# Altium schematic sheet ArcadeTestHAT 05-1.1 MCU.SchDoc
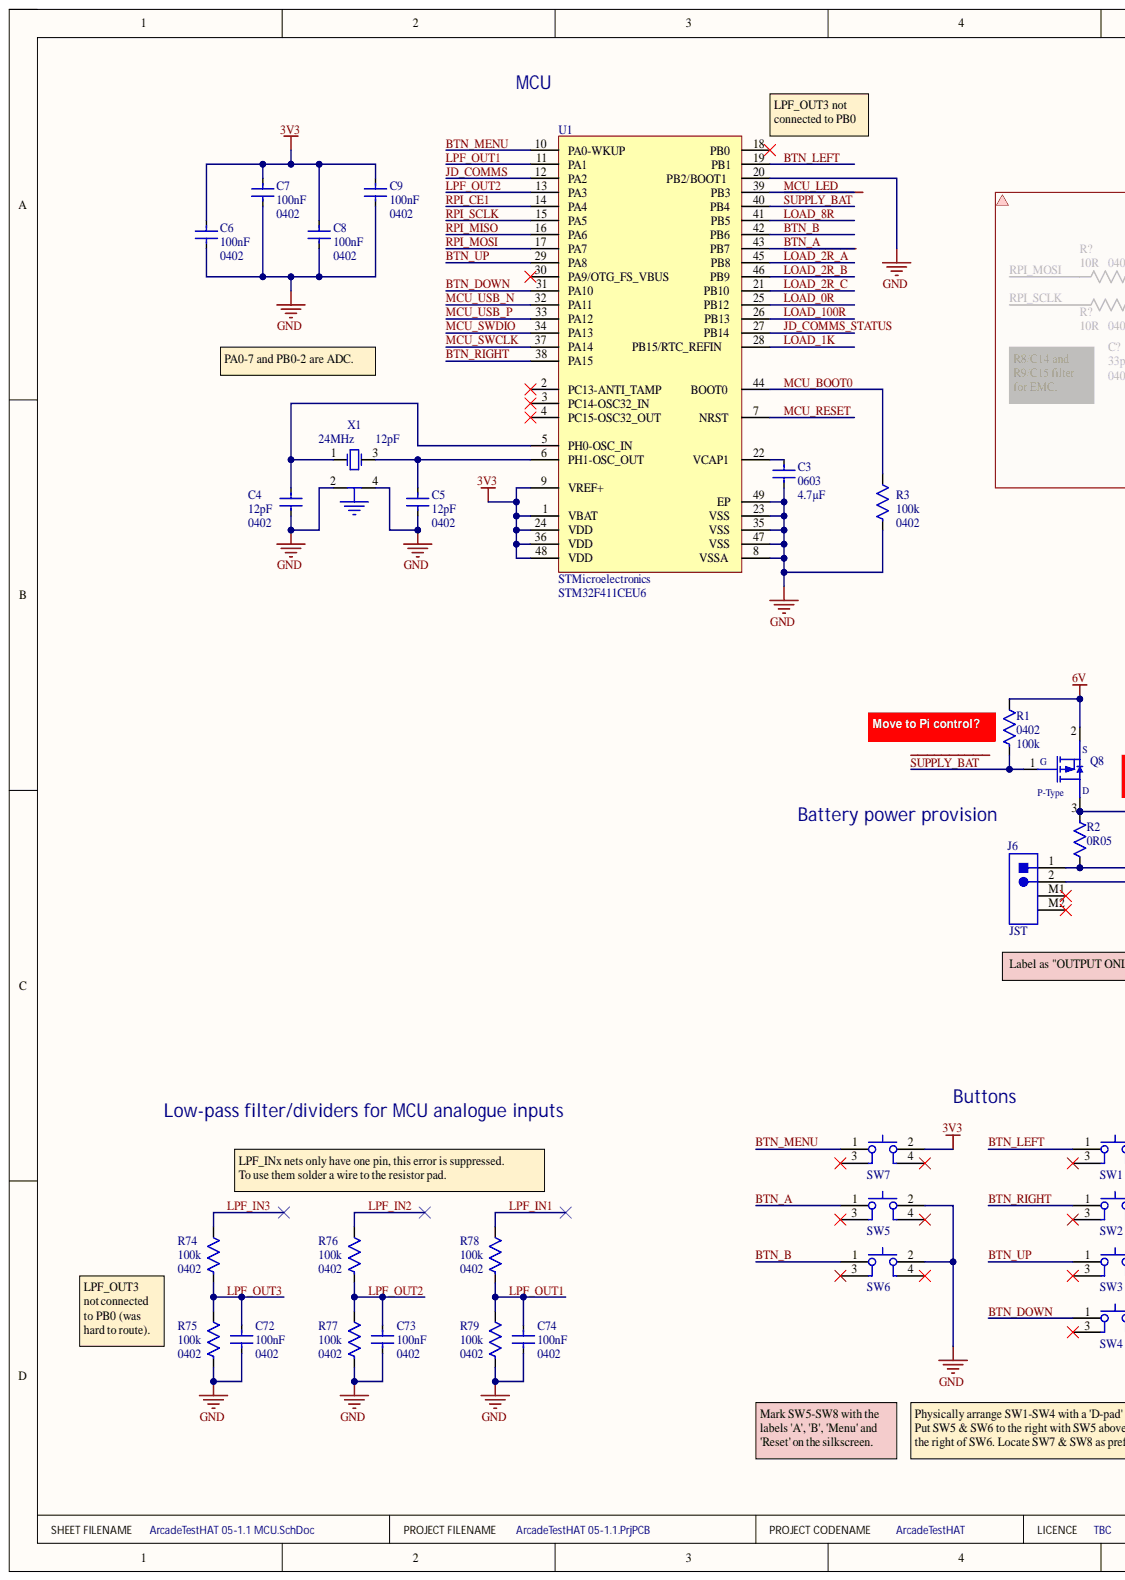
[diagram p0: ArcadeTestHAT 05-1.1 MCU.SchDoc, left]
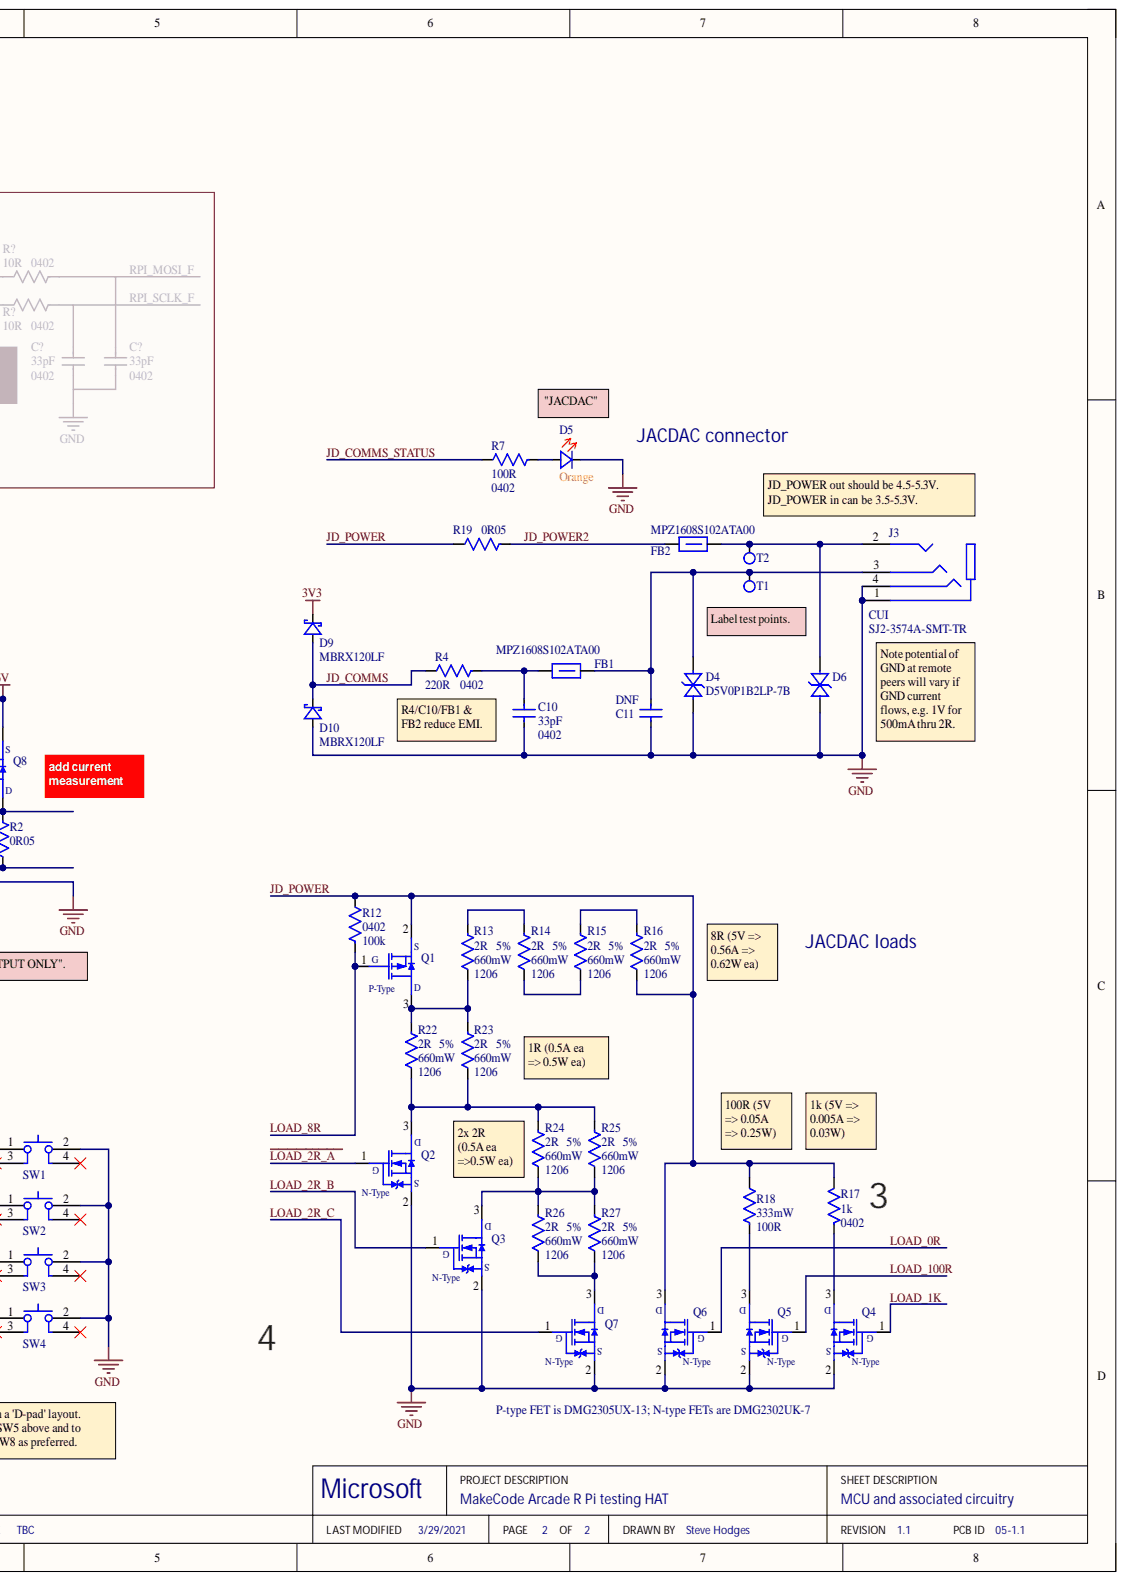
[diagram p1: ArcadeTestHAT 05-1.1 MCU.SchDoc, right]

## Parts
C3  Capacitance: 4.7µF  Case Code (Imperial): 0603
C4  value 12pF  Package Size: 0402
C5  value 12pF  Package Size: 0402
C6  Capacitance: 100nF  Case Code (Imperial): 0402
C7  Capacitance: 100nF  Case Code (Imperial): 0402
C8  Capacitance: 100nF  Case Code (Imperial): 0402
C9  Capacitance: 100nF  Case Code (Imperial): 0402
C10  value 33pF  Package Size: 0402
C11  (no value)
C72  Capacitance: 100nF  Case Code (Imperial): 0402
C73  Capacitance: 100nF  Case Code (Imperial): 0402
C74  Capacitance: 100nF  Case Code (Imperial): 0402
D4  Manufacturer Part Number 1: D5V0P1B2LP-7B
D5  Colour: Orange
D6  (no value)
D9  (no value)
D10  (no value)
FB1  Manufacturer Part Number: MPZ1608S102ATA00
FB2  Manufacturer Part Number: MPZ1608S102ATA00
J3  Manufacturer: CUI  Manufacturer Part Number: SJ2-3574A-SMT-TR
J6  Manufacturer: JST
Q1  (no value)
Q2  (no value)
Q3  (no value)
Q4  (no value)
Q5  Manufacturer Part Number: DMG2302UK-7
Q6  (no value)
Q7  (no value)
Q8  (no value)
R1  Case Code (Imperial): 0402  Resistance: 100k
R2  value 0R05
R3  Case Code (Imperial): 0402  Resistance: 100k
R4  value 220R  Case: 0402
R7  value 100R  Case: 0402
R12  Case Code (Imperial): 0402  Resistance: 100k
R13  Case Code (Imperial): 1206  Power Rating: 660mW  Resistance: 2R  Tolerance: 5%
R14  Case Code (Imperial): 1206  Power Rating: 660mW  Resistance: 2R  Tolerance: 5%
R15  Case Code (Imperial): 1206  Power Rating: 660mW  Resistance: 2R  Tolerance: 5%
R16  Case Code (Imperial): 1206  Power Rating: 660mW  Resistance: 2R  Tolerance: 5%
R17  value 1k  Case: 0402
R18  Max Power Dissipation: 333mW  Resistance: 100R
R19  value 0R05
R22  Case Code (Imperial): 1206  Power Rating: 660mW  Resistance: 2R  Tolerance: 5%
R23  Case Code (Imperial): 1206  Power Rating: 660mW  Resistance: 2R  Tolerance: 5%
R24  Case Code (Imperial): 1206  Power Rating: 660mW  Resistance: 2R  Tolerance: 5%
R25  Case Code (Imperial): 1206  Power Rating: 660mW  Resistance: 2R  Tolerance: 5%
R26  Case Code (Imperial): 1206  Power Rating: 660mW  Resistance: 2R  Tolerance: 5%
R27  Case Code (Imperial): 1206  Power Rating: 660mW  Resistance: 2R  Tolerance: 5%
R74  Case Code (Imperial): 0402  Resistance: 100k
R75  Case Code (Imperial): 0402  Resistance: 100k
R76  Case Code (Imperial): 0402  Resistance: 100k
R77  Case Code (Imperial): 0402  Resistance: 100k
R78  Case Code (Imperial): 0402  Resistance: 100k
R79  Case Code (Imperial): 0402  Resistance: 100k
SW1  (no value)
SW2  (no value)
SW3  (no value)
SW4  (no value)
SW5  (no value)
SW6  (no value)
SW7  (no value)
T1  (no value)
T2  (no value)
U1  Manufacturer: STMicroelectronics  Manufacturer Part Number: STM32F411CEU6
X1  Frequency: 24MHz  Loading capacitance: 12pF

## Nets
3V3: C6.2 C7.2 C8.2 C9.2 D9.1 SW7.2 U1.1(VBAT) U1.9(VREF+) U1.24(VDD) U1.36(VDD) U1.48(VDD)
6V: Q8.2 R1.1
GND: C3.1 C4.1 C5.1 C6.1 C7.1 C8.1 C9.1 C10.1 C11.1 C72.1 C73.1 C74.1 D4.2 D5.1 D6.2 D10.2 J3.1 J3.4 J6.2 Q2.2 Q3.2 Q4.2 Q5.2 Q6.2 Q7.2 R3.2 R75.2 R77.2 R79.2 SW1.2 SW2.2 SW3.2 SW4.2 SW5.2 SW6.2 U1.8(VSSA) U1.20(PB2/BOOT1) U1.23(VSS) U1.35(VSS) U1.47(VSS) U1.49(EP) X1.2 X1.4
~{LOAD_2R_A}: Q2.1 U1.45(PB8)
~{SUPPLY_BAT}: Q8.1 R1.2 U1.40(PB4)
BTN_A: SW5.1 U1.43(PB7)
BTN_B: SW6.1 U1.42(PB6)
BTN_DOWN: SW4.1 U1.31(PA10)
BTN_LEFT: SW1.1 U1.19(PB1)
BTN_MENU: SW7.1 U1.10(PA0-WKUP)
BTN_RIGHT: SW2.1 U1.38(PA15)
BTN_UP: SW3.1 U1.29(PA8)
JD_COMMS: D9.2 D10.1 R4.1 U1.12(PA2)
JD_COMMS_STATUS: R7.1 U1.27(PB14)
JD_POWER: Q1.2 Q6.3 R12.1 R16.2 R17.1 R18.1 R19.1
JD_POWER2: FB2.1 R19.2
LOAD_0R: Q6.1 U1.25(PB12)
LOAD_1K: Q4.1 U1.28(PB15/RTC_REFIN)
LOAD_2R_B: Q3.1 U1.46(PB9)
LOAD_2R_C: Q7.1 U1.21(PB10)
LOAD_8R: Q1.1 R12.2 U1.41(PB5)
LOAD_100R: Q5.1 U1.26(PB13)
LPF_IN1: R78.1
LPF_IN2: R76.1
LPF_IN3: R74.1
LPF_OUT1: C74.2 R78.2 R79.1 U1.11(PA1)
LPF_OUT2: C73.2 R76.2 R77.1 U1.13(PA3)
LPF_OUT3: C72.2 R74.2 R75.1
MCU_BOOT0: R3.1 U1.44(BOOT0)
MCU_LED: U1.39(PB3)
MCU_RESET: U1.7(NRST)
MCU_SWCLK: U1.37(PA14)
MCU_SWDIO: U1.34(PA13)
MCU_USB_N: U1.32(PA11)
MCU_USB_P: U1.33(PA12)
RPI_CE1: U1.14(PA4)
RPI_MISO: U1.16(PA6)
RPI_MOSI: U1.17(PA7)
RPI_SCLK: U1.15(PA5)
NetC3_2: C3.2 U1.22(VCAP1)
NetC4_2: C4.2 U1.5(PH0-OSC_IN) X1.1
NetC5_2: C5.2 U1.6(PH1-OSC_OUT) X1.3
NetC10_2: C10.2 FB1.1 R4.2
NetC11_2: C11.2 D4.1 FB1.2 J3.3 T1.1
NetD5_2: D5.2 R7.2
NetD6_1: D6.1 FB2.2 J3.2 T2.1
NetJ6_1: J6.1 R2.1
NetQ1_3: Q1.3 R13.2 R22.1 R23.1
NetQ2_3: Q2.3 R22.2 R23.2 R24.1 R25.1
NetQ3_3: Q3.3 R24.2 R25.2 R26.1 R27.1
NetQ4_3: Q4.3 R17.2
NetQ5_3: Q5.3 R18.2
NetQ7_3: Q7.3 R26.2 R27.2
NetQ8_3: Q8.3 R2.2
NetR13_1: R13.1 R14.1
NetR14_2: R14.2 R15.2
NetR15_1: R15.1 R16.1

Not connected: J6 M1 M2; SW1 3 4; SW2 3 4; SW3 3 4; SW4 3 4; SW5 3 4; SW6 3 4; SW7 3 4; U1 2(PC13-ANTI_TAMP) 3(PC14-OSC32_IN) 4(PC15-OSC32_OUT) 18(PB0) 30(PA9/OTG_FS_VBUS)

## Library data of the parts
C3 = (no symbol name): Generic 0603 capacitor 4.7uF 10V, e.g. Samsung CL10A475KP8NNNC; Dielectric: X5R; Tolerance: 10%; Case Code (Metric): 1608; Case/Package: 0603; Contact Plating: Tin; Manufacturer: Samsung; Manufacturer Part Number: CL10A475KP8NNNC; Max Operating Temperature: 85°C; Min Operating Temperature: -55°C; Supplier 1: Digi-Key; Supplier 2: Farnell; Supplier Part Number 1: 1276-1044-1-ND; Supplier Part Number 2: 3010071; Voltage Rating: 10V
C4 C5 = (no symbol name): Generic 0402 capacitor 12pF; Rated Voltage: * V; Manufacturer: Generic
C6-C9 C72-C74 = (no symbol name): Generic 0402 capacitor 100nF 16V, e.g. AVX 0402YG104ZAT2A; Manufacturer: Kyocera AVX; Dielectric: Y5V; Dissipation Factor: 9%; Insulation Resistance: 100GR; Tolerance: 80%; Case Code (Metric): 1005; Case/Package: 0402; ComponentLink2Description: AVX datasheet online; ComponentLink2URL: http://datasheets.avx.com/Y5V.pdf; Contact Plating: Tin,Matte; Manufacturer Part Number: 0402YG104ZAT2A; Max Operating Temperature: 85°C; Min Operating Temperature: -30°C; Supplier 1: Digi-Key; Supplier 2: Farnell; Supplier 3: Mouser; Supplier 4: RSComponents; Supplier Part Number 1: 478-1138-1-ND; Supplier Part Number 2: 1327667; Supplier Part Number 3: 581-040216G104Z; Supplier Part Number 4: 698-3190; Termination: SMD/SMT; Voltage: 16V; Voltage Rating: 16V; Voltage Rating (DC): 16V
C10 = (no symbol name): Generic 0402 capacitor 33pF; Rated Voltage: * V; Manufacturer: Generic
C11 = (no symbol name): generic 0402 capacitor; Rated Voltage: * V; Value: 33pF; Package Size: 0402
D4 = (no symbol name): ESD Suppressor, low parasitic capacitance.TVS DIODE 5.5V 13V DFN1006-2; Manufacturer 1: Diodes; Breakdown Voltage: 7V; Direction: Bidirectional; Element Configuration: Single; ESD Protection: Yes; Max Power Dissipation: 250mW; Max Reverse Leakage Current: 100nA; Max Surge Current: 4A; Min Breakdown Voltage: 6V; Number of Bidirectional Channels: 1; Power Line Protection: No; Case/Package: DFN; Category: TVS Diodes; Clamping Voltage: 13V; ComponentLink3Description: https://www.diodes.com/assets/Datasheets/D5V0P1B2LP.pdf?src-supplier=Digi-Key; ComponentLink3URL: https://www.diodes.com/assets/Datasheets/D5V0P1B2LP.pdf?src-supplier=Digi-Key; Contact Plating: Gold; Max Operating Temperature: 150°C; Min Operating Temperature: -65°C; Number of Pins: 2; Peak Pulse Current: 4A; Peak Pulse Power: 250mW; Polarity: Bidirectional; Resistor Type: Zener; Reverse Standoff Voltage: 5.5V; Supplier 1: Digi-Key; Supplier 2: Mouser; Supplier Part Number 1: D5V0P1B2LP-7BDICT-ND; Supplier Part Number 2: 621-D5V0P1B2LP-7B; Termination: SMD/SMT; Working Voltage: 5.5V
D5 = (no symbol name): 1.6 x 0.8 x 0.55mm (0603) LED 605nm Orange; Manufacturer: Rohm Semiconductor; Manufacturer Part Number: SML-D12D8WT86; Current - Test: 20mA; Lens Style/Size: Rectangle with Flat Top, 1.2mm x 0.8mm; Lens Type: Diffused, White; Millicandela Rating: 100mcd; Voltage - Forward (Vf) (Typ): 2.2V; Category: Optoelectronics; ComponentLink1Description: http://rohmfs.rohm.com/en/products/databook/datasheet/opto/led/chip_mono/sml-d1.pdf; ComponentLink1URL: http://rohmfs.rohm.com/en/products/databook/datasheet/opto/led/chip_mono/sml-d1.pdf; Luminous Flux @ Current - Test: -; Package / Case: 0603 (1608 Metric); Size / Dimension: 1.60mm L x 0.80mm W; Supplier 1: Digi-Key; Supplier Part Number 1: 511-1577-1-ND; Viewing Angle: -; Wavelength - Dominant: 605nm; Wavelength - Peak: -
D6 = (no symbol name): ESD Suppressor, low parasitic capacitance.TVS DIODE 5.5V 13V DFN1006-2; Manufacturer 1: Diodes; Manufacturer Part Number 1: D5V0P1B2LP-7B; Breakdown Voltage: 7V; Direction: Bidirectional; Element Configuration: Single; ESD Protection: Yes; Max Power Dissipation: 250mW; Max Reverse Leakage Current: 100nA; Max Surge Current: 4A; Min Breakdown Voltage: 6V; Number of Bidirectional Channels: 1; Power Line Protection: No; Case/Package: DFN; Category: TVS Diodes; Clamping Voltage: 13V; ComponentLink3Description: https://www.diodes.com/assets/Datasheets/D5V0P1B2LP.pdf?src-supplier=Digi-Key; ComponentLink3URL: https://www.diodes.com/assets/Datasheets/D5V0P1B2LP.pdf?src-supplier=Digi-Key; Contact Plating: Gold; Max Operating Temperature: 150°C; Min Operating Temperature: -65°C; Number of Pins: 2; Peak Pulse Current: 4A; Peak Pulse Power: 250mW; Polarity: Bidirectional; Resistor Type: Zener; Reverse Standoff Voltage: 5.5V; Supplier 1: Digi-Key; Supplier 2: Mouser; Supplier Part Number 1: D5V0P1B2LP-7BDICT-ND; Supplier Part Number 2: 621-D5V0P1B2LP-7B; Termination: SMD/SMT; Working Voltage: 5.5V
D9 D10 = (no symbol name): Schottky diode, 1A low Vf; Manufacturer: MCC; Manufacturer Part Number: MBRX120LF-TP; Element Configuration: Single; Forward Voltage: 360mV; Max Forward Surge Current (Ifsm): 12A; Max Power Dissipation: 556mW; Max Repetitive Reverse Voltage (Vrrm): 20V; Average Rectified Current: 1A; ComponentLink1Description: MCC datasheet; ComponentLink1URL: http://www.mccsemi.com/up_pdf/MBRX120LF(SOD-123).pdf; Forward Current: 1A; Max Operating Temperature: 125°C; Max Reverse Voltage (DC): 20V; Max Surge Current: 12A; Min Operating Temperature: -65°C; Number of Pins: 2; Peak Non-Repetitive Surge Current: 12A; Supplier 1: Digi-Key; Supplier 2: Farnell; Supplier 3: Mouser; Supplier Part Number 1: MBRX120LF-TPMSCT-ND; Supplier Part Number 2: 1924371; Supplier Part Number 3: 833-MBRX120LF-TP
FB1 FB2 = (no symbol name): 0603 1k@100MHz 0.8A chip; Manufacturer: TDK; Core Material: Ferrite; Current Rating: 800mA; DC Resistance (DCR): 300mR; Impedance: 1kR @ 100MHz; Tolerance: 25%; Case Code (Imperial): 0603; Case Code (Metric): 1608; Case/Package: 0603; ComponentLink2Description: datasheet online; ComponentLink2URL: https://www.mouser.co.uk/datasheet/2/400/eads_commercial_power_mpz1608_en-923650.pdf; ComponentLink3Description: datasheet; Max DC Current: 800mA; Supplier 1: Digi-Key; Supplier 2: Mouser; Supplier Part Number 1: 445-8694-1-ND; Supplier Part Number 2: 810-MPZ1608S102ATA00; ComponentLink3URL: Y:\System\Altium\Libraries 2014\TDK\Datasheets\eads_commercial_power_mpz1608_en-923650.pdf
J3 = (no symbol name): 4-way 3.5mm jack socket SMD R/A; Number of Conductors: 4; Number of Ports: 1; Shielding: Unshielded; Color: Black; ComponentLink1Description: CUI datasheet; ComponentLink1URL: https://www.cui.com/product/resource/sj2-357x-smt.pdf; Connector Type: Jack; Orientation: Horizontal; Supplier 1: Digi-Key; Supplier 2: Mouser; Supplier Part Number 1: CP-SJ2-3574A-SMT-CT-ND; Supplier Part Number 2: 490-SJ2-3574A-SMT-TR
J6 = (no symbol name): Male header, pitch 2 mm, 1x2 position; Manufacturer Part Number: S2B-PH-SM4-TB(LF)(SN); Connector Type: Male Pin; Contact Resistance: 20mR; Current Rating: 2A; Insulation Resistance: 1GR; Max Voltage Rating (AC): 100V; Number of Contacts: 2; Pitch: 2mm; Color: Natural,White; ComponentLink1Description: JST connector selector website; ComponentLink1URL: http://www.jst.com/home2.html; ComponentLink2Description: JST datasheet; ComponentLink2URL: http://www.jst-mfg.com/product/pdf/eng/ePH.pdf; Contact Material: Copper Alloy,Bronze,Tin,Brass; Contact Mating Length: 0.13inch; Contact Plating: Tin,Copper; Fastening Type: Detent; Flammability Rating: UL94 V-0; Gender: Male; Housing Color: Beige; Housing Material: Polyamide; Lead Pitch: 2mm; Max Current Rating: 2A; Max Operating Temperature: 85°C; Max Voltage Rating (DC): 100V; Min Operating Temperature: -25°C; Number of Poles: 2; Number of Positions: 2; Number of Rows: 1; Orientation: Right Angle; Supplier 1: Digi-Key; Supplier 2: Farnell; Supplier 3: RSComponents; Supplier Part Number 1: 455-1749-1-ND; Supplier Part Number 2: 9492615; Supplier Part Number 3: 688-1353; Termination: Solder; Voltage Rating: 100V; Voltage Rating (AC): 100V; Working Voltage: 100V
Q1 Q8 = (no symbol name): MOSFET P-CH 20V 4.2A SOT23; Manufacturer: Diodes; Manufacturer Part Number: DMG2305UX-13; Drain to Source Breakdown Voltage: -20V; Drain to Source Resistance: 52mR; Element Configuration: Single; Fall Time: 34.7ns; Gate to Source Voltage (Vgs): 8V; Input Capacitance: 808pF; Max Power Dissipation: 1.4W; Number of Channels: 1; On-State Resistance: 65MR; Rise Time: 13.7ns; Threshold Voltage: -900mV; Turn-Off Delay Time: 79.3ns; Turn-On Delay Time: 10.8ns; Case/Package: TO-236-3; ComponentLink2Description: online datasheet; ComponentLink2URL: https://datasheet.ciiva.com/18566/dmg2305ux-18566951.pdf; ComponentLink3Description: datasheet; Continuous Drain Current (ID): 4.2A; Drain to Source Voltage (Vdss): 20V; Max Operating Temperature: 150°C; Min Operating Temperature: -55°C; Number of Pins: 3; Rds On Max: 52mR; Supplier 1: Digi-Key; Supplier 2: Farnell; Supplier 3: Mouser; Supplier 4: RSComponents; Supplier Part Number 1: DMG2305UX-13DICT-ND; Supplier Part Number 2: 2543533; Supplier Part Number 3: 621-DMG2305UX-7; Supplier Part Number 4: 8270452; Weight: 0.000282oz; ComponentLink3URL: Y:\System\Altium\Libraries 2014\Diodes Inc\Datasheets\dmg2305ux-30023840.pdf
Q2-Q4 Q6 Q7 = (no symbol name): MOSFET N-CH 20V 2.8A SOT23; Manufacturer: Diodes; Manufacturer Part Number: DMG2302UK-7; Input Capacitance: 130pF; Max Power Dissipation: 660mW; Rds On Max: 90mR; Case/Package: TO-236-3; ComponentLink2Description: online datasheet; ComponentLink2URL: https://www.diodes.com/assets/Datasheets/DMG2302UK.pdf; ComponentLink3Description: datasheet; Continuous Drain Current (ID): 2.8A; Drain to Source Voltage (Vdss): 20V; Max Operating Temperature: 150°C; Min Operating Temperature: -55°C; Supplier 1: Digi-Key; Supplier 2: Farnell; Supplier 3: Mouser; Supplier 4: RSComponents; Supplier Part Number 1: DMG2302UK-7DICT-ND; Supplier Part Number 2: 3127315; Supplier Part Number 3: 621-DMG2302UK-7; Supplier Part Number 4: 1333362; ComponentLink3URL: Y:\System\Altium\Libraries 2014\Diodes Inc\Datasheets\DMG2302UK.pdf
Q5 = (no symbol name): MOSFET N-CH 20V 2.8A SOT23; Manufacturer: Diodes; Input Capacitance: 130pF; Max Power Dissipation: 660mW; Rds On Max: 90mR; Case/Package: TO-236-3; ComponentLink2Description: online datasheet; ComponentLink2URL: https://www.diodes.com/assets/Datasheets/DMG2302UK.pdf; ComponentLink3Description: datasheet; Continuous Drain Current (ID): 2.8A; Drain to Source Voltage (Vdss): 20V; Max Operating Temperature: 150°C; Min Operating Temperature: -55°C; Supplier 1: Digi-Key; Supplier 2: Farnell; Supplier 3: Mouser; Supplier 4: RSComponents; Supplier Part Number 1: DMG2302UK-7DICT-ND; Supplier Part Number 2: 3127315; Supplier Part Number 3: 621-DMG2302UK-7; Supplier Part Number 4: 1333362; ComponentLink3URL: Y:\System\Altium\Libraries 2014\Diodes Inc\Datasheets\DMG2302UK.pdf
R1 = (no symbol name): Generic 0402 resistor 100k, e.g. Multicomp MCWR04X1003FTL; Power Rating: 62.5mW; Temperature Coefficient: 100ppm/°C; Tolerance: 1%; Voltage Rating: 50V; Case Code (Metric): 1005; Case/Package: 0402; ComponentLink1Description: Multicomp datasheet online; ComponentLink1URL: http://www.farnell.com/datasheets/1849611.pdf?src-supplier=Element14; ComponentLink2Description: datasheet; Composition: Thick Film; Manufacturer: Multicomp; Manufacturer Part Number: MCWR04X1003FTL; Supplier 1: Farnell; Supplier Part Number 1: 2447094; Voltage Rating (DC): 50V; ComponentLink2URL: Y:\System\Altium\Libraries 2014\Multicomp\datasheets\thick film chip resistors 1849611.pdf
R2 R19 = (no symbol name): Generic 1206 resistor 0.05R 1% 0.5W, e.g. Ohmite KDV12FR050ET; Power: 500mW; ComponentLink1Description: Ohmite datasheet online; ComponentLink1URL: https://www.ohmite.com/assets/docs/res_kdv.pdf; Manufacturer: Ohmite; Manufacturer Part Number: KDV12FR050ET; Package Size: 1206; Supplier 1: Digi-Key; Supplier 2: Farnell; Supplier 3: Mouser; Supplier Part Number 1: 273-KDV12FR050ETCT-ND; Supplier Part Number 2: 3273730; Supplier Part Number 3: 588-KDV12FR050ET; Tolerance: 1%
R3 R12 R74-R79 = (no symbol name): generic 0402 resistor 100k, e.g. Multicomp MCWR04X1003FTL; Power Rating: 62.5mW; Temperature Coefficient: 100ppm/°C; Tolerance: 1%; Voltage Rating: 50V; Case Code (Metric): 1005; Case/Package: 0402; ComponentLink1Description: Multicomp datasheet online; ComponentLink1URL: http://www.farnell.com/datasheets/1849611.pdf?src-supplier=Element14; ComponentLink2Description: datasheet; Composition: Thick Film; Manufacturer: Multicomp; Manufacturer Part Number: MCWR04X1003FTL; Supplier 1: Farnell; Supplier Part Number 1: 2447094; Voltage Rating (DC): 50V; ComponentLink2URL: Y:\System\Altium\Libraries 2014\Multicomp\datasheets\thick film chip resistors 1849611.pdf
R4 = (no symbol name): Generic 0402 resistor 220R; Manufacturer: Generic
R7 = (no symbol name): Generic 0402 resistor 100R; Manufacturer: Generic
R13-R16 R22-R27 = (no symbol name): Generic 1206 resistor 2R 0.33W 5%, e.g. Panasonic ERJ-P08J2R0V; Temperature Coefficient: 600ppm/°C; Voltage Rating: 500V; Voltage Rating (DC): 200V; Case Code (Metric): 3216; Case/Package: 1206; ComponentLink1Description: Panasonic datasheet online; ComponentLink1URL: https://industrial.panasonic.com/cdbs/www-data/pdf/RDO0000/AOA0000C331.pdf; Composition: Thick Film; Manufacturer: Panasonic; Manufacturer Part Number: ERJ-P08J2R0V; Max Operating Temperature: 155°C; Min Operating Temperature: -55°C; Number of Pins: 2; Supplier 1: Digi-Key; Supplier 2: Farnell; Supplier 3: Mouser; Supplier 4: RSComponents; Supplier Part Number 1: ERJ-P08J2R0V-ND; Supplier Part Number 2: 1894401; Supplier Part Number 3: 667-ERJ-P08J2R0V; Supplier Part Number 4: 721-8627; Termination: SMD/SMT
R17 = (no symbol name): Generic 0402 resistor 1k; Manufacturer: Generic
R18 = (no symbol name): Generic 0805 resistor 100R 5% 1/3W, e.g. Ohmite AS08J1000ET; Temperature Coefficient: 100ppm/°C; Case/Package: 0805; ComponentLink1Description: Ohmite datasheet online; ComponentLink1URL: https://www.mouser.co.uk/datasheet/2/303/ohmite_res_as_smd-1189203.pdf; Composition: Thick Film; Manufacturer: Ohmite; Manufacturer Part Number: AS08J1000ET; Max Operating Temperature: 155°C; Min Operating Temperature: -55°C; Number of Terminations: 2; Supplier 1: Digi-Key; Supplier 2: Mouser; Supplier Part Number 1: AS08J1000ET-ND; Supplier Part Number 2: 588-AS08J1000ET; Tolerance: 5%
SW1-SW7 = (no symbol name): Generic 6x6mm SPST button e,g, Wurth 430152050826; Manufacturer: Wurth Electronics; Actuator Length: 1.6mm; Actuator Material: Polymer,Liquid Crystal Polymer; Actuator Type: Standard; Contact Current Rating: 50mA; Illumination Type: Non-Illuminated,Illuminated; Mechanical Life: 200000Cycles; Operating Force: 260gf; Switch Function: Off-Mom; Switch Travel: 0.25mm; ComponentLink1Description: wurth datasheet online; ComponentLink1URL: http://www.farnell.com/datasheets/1910150.pdf?src-supplier=Element14; ComponentLink2Description: wurth datasheet; Contact Material: Stainless Steel,Steel; Current Rating: 50mA; Manufacturer Part Number: 430152050826; Max Operating Temperature: 85°C; Min Operating Temperature: -40°C; Supplier 1: Digi-Key; Supplier 2: Farnell; Supplier 3: RSComponents; Supplier Part Number 1: 732-6993-1-ND; Supplier Part Number 2: 2065110; Supplier Part Number 3: 7856213; Termination: Gull Wing; Throw Configuration: SPST; ComponentLink2URL: Y:\System\Altium\Libraries 2014\Wurth\Datasheets\4301x2xxx8x6 1910150.pdf
T1 T2 = (no symbol name): Test Point
U1 = (no symbol name): ARM Cortex M4 MCU+FPU 512k Flash, 128k RAM, 100MHz, QFPN48; Data Bus Width: 32b; Interface: I2C,I2S,IrDA,LIN,MMC,SD,SDIO,SPI,UART,USART,USB; Max Power Dissipation: 625mW; Max Supply Voltage: 3.6V; Memory Size: 512KB; Min Supply Voltage: 1.7V; Number of ADC Channels: 16; Number of I/Os: 36; Number of Timers/Counters: 8; Operating Supply Voltage: 3.3V; Oscillator Type: Internal; Peripherals: Brown-out Detect/Reset,DMA,POR,PWM,WDT; RAM Size: 128KB; Watchdog Timer: Yes; Case/Package: UFQFPN; ComponentLink1Description: ST datasheet; ComponentLink1URL: https://www.st.com/resource/en/datasheet/stm32f411vc.pdf; Core Architecture: ARM; Frequency: 100MHz; Max Frequency: 100MHz; Max Operating Temperature: 85°C; Memory Type: FLASH; Min Operating Temperature: -40°C; Number of Pins: 48; Number of Programmable I/O: 36; Supplier 1: Digi-Key; Supplier 2: Farnell; Supplier 3: Mouser; Supplier 4: RSComponents; Supplier Part Number 1: 497-14907-ND; Supplier Part Number 2: 2456965; Supplier Part Number 3: 511-STM32F411CEU6; Supplier Part Number 4: 1106616
X1 = (no symbol name): Generic 3.2x2.5mm 24MHz 12pF SMD xtal, e.g. Multicomp MCSJK-7U-24.00-12-10-60-B-10; ESR: 60R; Tolerance: ±10ppm; ComponentLink1Description: online datasheet; ComponentLink1URL: http://www.farnell.com/datasheets/2645227.pdf?_ga=2.202843601.1706070776.1558802095-240913015.1522930266; ComponentLink2Description: datasheet; Manufacturer: Multicomp; Manufacturer Part Number: MCSJK-7U-24.00-12-10-60-B-10; Supplier 1: Farnell; Supplier Part Number 1: 2853960; ComponentLink2URL: Y:\System\Altium\Libraries 2014\Multicomp\datasheets\MCSJK-7U 3.2x2.5mm SMD xtals.pdf
